# Altium schematic sheet Top.SchDoc(Top)
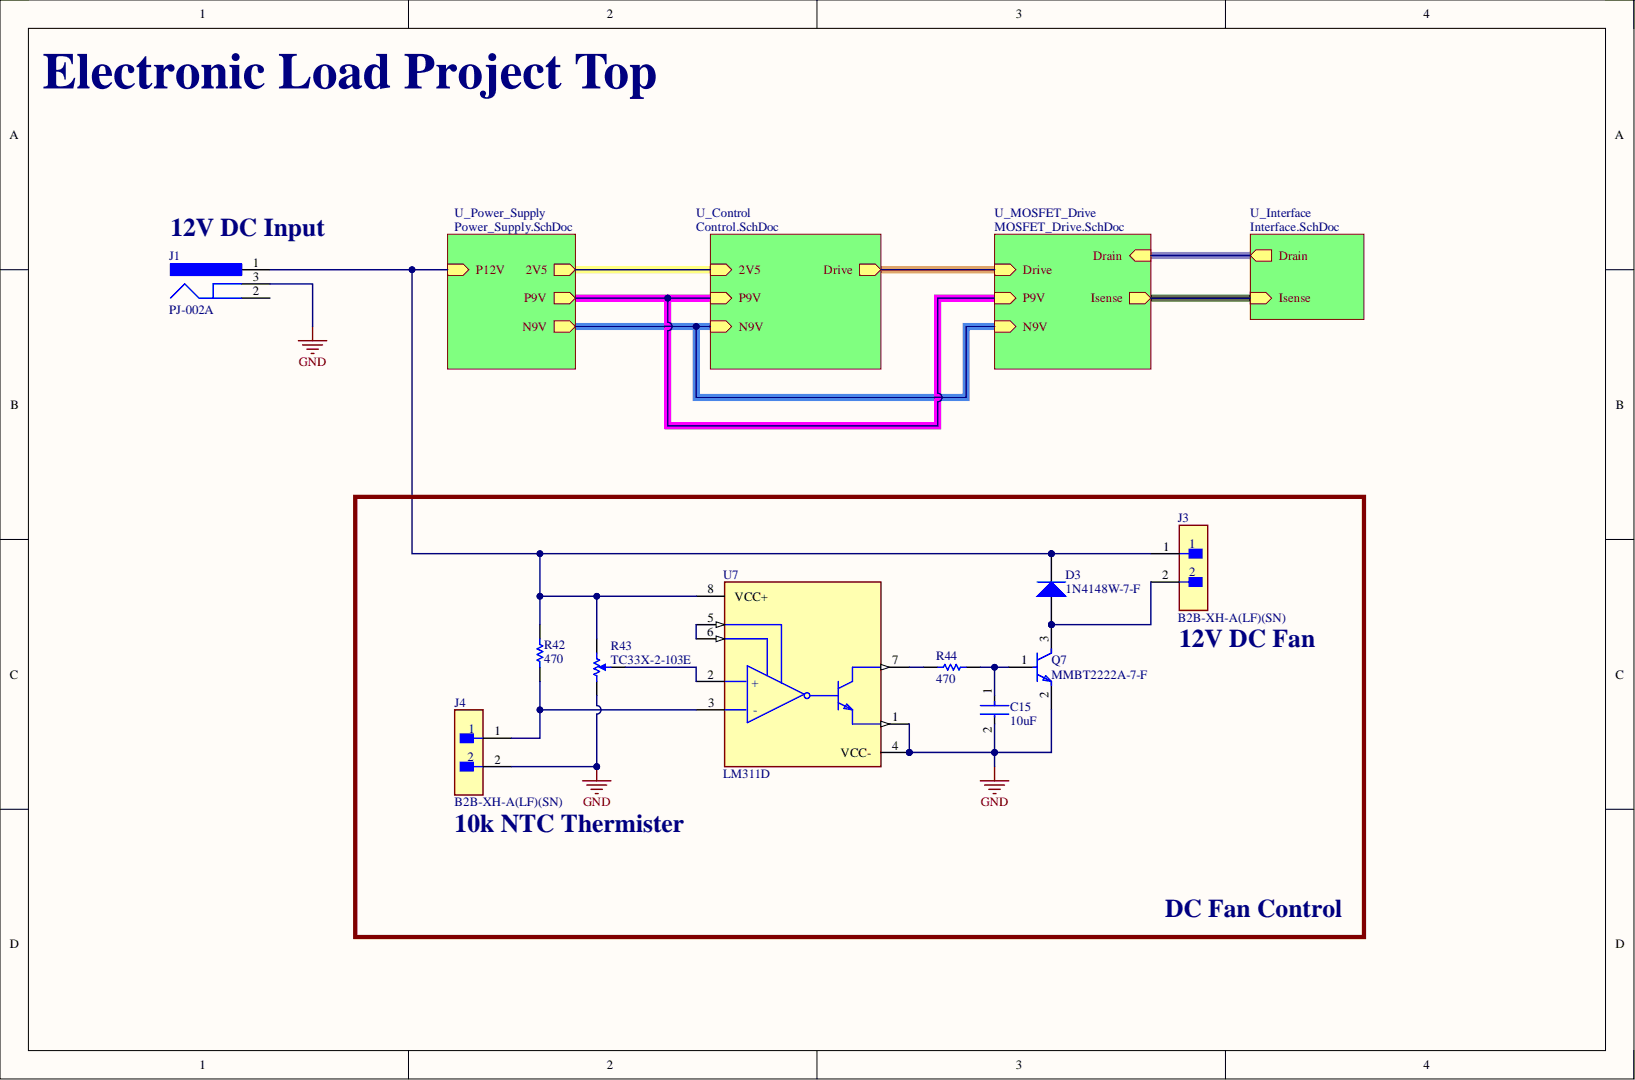
[diagram p0: Top.SchDoc]

## Parts
C15  10uF  [FP-C1210C107M9PACTU-MFG]
D3  1N4148W-7-F  [DIOD-SOD123-2_V]
J1  PJ-002A  [CUI-PJ-002A_V]
J3  B2B-XH-A(LF)(SN)  [FP-B2B-XH-A_LF_SN-MFG]
J4  B2B-XH-A(LF)(SN)  [FP-B2B-XH-A_LF_SN-MFG]
Q7  MMBT2222A-7-F  [FP-SOT23-IPC_A]
R42  470  [RESC2013X70X40NL20T20]
R43  TC33X-2-103E  [BOUR-TC33X-2_V]
R44  470  [RESC2013X70X40NL20T20]
U7  LM311D  [FP-D0008A-MFG]

## Nets
GND: C15.2 J1.3 J4.2 Q7.2 R43.1 U7.1 U7.4(VCC-)
NetC15_1: C15.1 Q7.1 R44.2
NetD3_1: D3.1 J3.2 Q7.3
NetD3_2: D3.2 J1.1 J3.1 R42.2 R43.3 U7.8(VCC+)
NetJ1_2: J1.2
NetJ4_1: J4.1 R42.1 U7.3
NetR43_2: R43.2 U7.2
NetR44_1: R44.1 U7.7
NetU7_5: U7.5 U7.6
2V5:
Drain:
Drive:
Isense:
N9V:
P9V:

## Library data of the parts
C15 = CMP-2000-06018-2: C1210C107M9PACTU  CAP CER 100UF 6.3V X5R 1210; Capacitance: 2.2uF; Dielectric Material: Ceramic; Dissipation Factor: 5%; Tolerance: 20%; Case Code (Imperial): 1210; Case Code (Metric): 3225; Case/Package: 1210; Component Kind: Standard; Construction: Flat; Contact Plating: Tin; Depth: 2.5mm; Dielectric: X5R; Height: 2.5mm; Lead Free: Lead Free; Length: 3.2mm; Library Name: Altium Content Vault; Max Operating Temperature: 85°C; Min Operating Temperature: -55°C; Mount: Surface Mount; Number of Pins: 2; Package Quantity: 1; Packaging: Tape and Reel; Radiation Hardening: No; REACH SVHC: No SVHC; RoHS Compliant: Yes; Series: C; Termination: SMD/SMT; Thickness: 0.11inch; Voltage: 6.3V; Voltage Rating: 6.3V; Voltage Rating (DC): 6.3V; Width: 2.5mm
D3 = CMP-2000-04932-2: Fast Switching Diode, 100 V, 0.15 A, -65 to 150 degC, 2-Pin?SOD123, RoHS, Tape and Reel; Brand: DiodesIncorporated; Configuration: Single; If - Forward Current: 0.15A; Ir - Reverse Current: 1uA; Max Surge Current: 2A; Peak Reverse Voltage: 100V; Recovery Time: 4ns; Unit Weight: 0.000353oz; Vf - Forward Voltage: 1.25V; Component Kind: Standard; Datasheet URL: http://www.farnell.com/datasheets/1915529.pdf; Datasheet Version: Rev. 24-2, 10/2013; Factory Pack Quantity: 3000; Height: 1.25mm; Length: 2.85mm; Library Name: Altium Content Vault; Manufacturer: DiodesIncorporated; Manufacturer URL: http://www.diodes.com/; Maximum Operating Temperature: +150C; Minimum Operating Temperature: -65C; Mounting Style: SMD/SMT; Operating Temperature Range: -65Cto+150C; Package / Case: SOD-123; Package Reference: SOD123-2; Package URL: http://www.diodes.com/datasheets/ds18004.pdf; Packaging: Reel; Product: SwitchingDiodes; Product Category: Diodes-GeneralPurpose,Power,Switching; RoHS: True; Series: 1N4148; Type: SwitchingDiode; Width: 1.7mm
J1 = CMP-2000-06895-2: Through Hole Right Angle DC Power Jack, 2.5 A, 2 mm Center Pin, 3 Position, -25 to 85 degC, RoHS, Bulk; Manufacturer: CUIInc.; Brand: CUI; Current Rating: 2.5A; Inside Contact Diameter: 2mm; Insulation Resistance: 50MOhms; Outside Contact Diameter: 6.5mm; Voltage Rating: 24V; Component Kind: Standard; Connector Type: DCPowerJack; Contact Gender: Male; Contact Material: Copper; Contact Plating: Nickel; Datasheet URL: http://www.cui.com/product/resource/pj-002a.pdf; Datasheet Version: Rev. 1.03, 04/2016; Factory Pack Quantity: 500; Flammability Rating: UL94V-0; Housing Material: PolybutyleneTerephthalate(PBT); Library Name: Altium Content Vault; Manufacturer URL: http://www.cui.com/; Mounting Angle: Right; Mounting Style: ThroughHole; Mounting Technology: ThroughHole; Operating Temperature Range: -25Cto+85C; Package Description: 3-Pin Through Hole Device, Body 14.4 x 9 mm, Height 11 mm; Package Reference: PJ-002A; Product: Jacks; Product Category: DCPowerConnectors; RoHS: True; Termination Style: ThroughHole
J3 J4 = CMP-2000-05888-3: CONN HEADER VERT 2POS 2.5MM; Connector Type: Male Pin; Contact Resistance: 20mR; Current Rating: 3A; Insulation Resistance: 1GR; Max Voltage Rating (AC): 250V; Number of Contacts: 2; Wire/Cable Gauge: 22AWG; Color: Natural; Component Kind: Standard; Contact Material: Brass; Contact Plating: Tin; Contact Type: Male Pin; Depth: 5.75mm; Fastening Type: Detent; Housing Color: White; Housing Material: Polyamide; Lead Free: Lead Free; Lead Pitch: 2.5mm; Length: 7.4mm; Library Name: Altium Content Vault; Max Current Rating: 3A; Max Operating Temperature: 85°C; Max Voltage Rating (DC): 250V; Min Operating Temperature: -25°C; Mount: Through Hole; Number of Positions: 2; Number of Rows: 1; Orientation: Straight; Packaging: Bulk; Pitch: 2.5mm; REACH SVHC: No SVHC; Series: XH; Termination: Solder; Voltage Rating: 250V; Voltage Rating (AC): 250V
Q7 = CMP-1693-00003-4: TRANS NPN 40V 0.6A SMD SOT23-3; Collector Base Voltage (VCBO): 75V; Collector Emitter Saturation Voltage: 1V; Continuous Collector Current: 600mA; Current: 1A; Element Configuration: Single; Emitter Base Voltage (VEBO): 6V; Frequency: 300MHz; hFE Min: 100; Max Power Dissipation: 300mW; Number of Elements: 1; Power Dissipation: 350mW; Case/Package: SOT-23; Collector Emitter Breakdown Voltage: 40V; Collector Emitter Voltage (VCEO): 40V; Component Kind: Standard; Contact Plating: Tin; Current Rating: 600mA; Gain Bandwidth Product: 300MHz; Height: 1mm; Lead Free: Lead Free; Length: 3.05mm; Library Name: Altium Content Vault; Max Breakdown Voltage: 40V; Max Collector Current: 600mA; Max Frequency: 300MHz; Max Operating Temperature: 150°C; Min Operating Temperature: -55°C; Mount: Surface Mount; Number of Pins: 3; Package Quantity: 3000; Packaging: Tape and Reel; Polarity: NPN; Radiation Hardening: No; REACH SVHC: No SVHC; Termination: SMD/SMT; Transition Frequency: 300MHz; Voltage: 40V; Voltage Rating (DC): 40V; Weight: 0.000282oz; Width: 1.4mm
R42 R44 = CMP-00023-1: 0805, 0.125W, 1%, Low PPM; Max Power Dissipation: 125mW; Resistance Tolerance: 5%; Temperature Coefficient: 200ppm/°C; Voltage Rating: 150V; Case Code (Imperial): 0805; Case Code (Metric): 2012; Case/Package: 0805; Component Kind: Standard; Construction: Rectangular; Contact Plating: Tin; Depth: 1.25mm; Height: 0.6mm; Length: 2mm; Max Operating Temperature: 155°C; Military Standard: Not; Min Operating Temperature: -55°C; Mounting Technology: Surface Mount; Number of Terminations: 2; Package Quantity: 1; Packaging: Tape and Reel; Power Rating: 125mW; Radiation Hardening: No; Reach SVHC Compliant: No; Resistor Type: Thick Film; Series: ERJ; Technology: THICK FILM; Termination: SMD/SMT; Tolerance: 5%; Value: 470; Width: 1.25mm; Library Name: UBC AeroDesign
R43 = CMP-2100-03695-1: Trimming Potentiometer, 10000 Ohm, -40 to 100 degC, 3-Pin SMD, RoHS, Tape and Reel; Manufacturer: Bourns; Max Power Dissipation: 100mW; Number of Turns: 1; Resistance: 10KOhm; Temperature Coefficient: 250ppm/degreesC; Tolerance: 25%; Voltage Rating (DC): 50V; Case/Package: SMD/SMT; Colour: Silver; Component Kind: Standard; Composition: Potentiometer; Contact Plating: Silver; Datasheet URL: http://www.bourns.com/docs/Product-Datasheets/TC33.pdf; Datasheet Version: 42583.0; Depth: 3.8mm; Height: 0.047inch; Lead Free: LeadFree; Length: 3mm; Library Name: Altium Content Vault; Manufacturer URL: http://www.bourns.com/; Max Operating Temperature: 100degC; Military Standard: Not; Min Operating Temperature: -40degC; Mount: SurfaceMount; Package Description: 3-Pin Surface Mount Device, Body 3.8 x 3.6 mm; Package Quantity: 2500; Package Reference: TC33X-2; Packaging: TapeandReel; Power Rating: 100mW; Radiation Hardening: No; REACH SVHC: NoSVHC; RoHSCompliant: true; Taper: Linear; Termination: FootPrint; Weight: 29.4mg; Width: 0.118inch
U7 = CMP-04896-000022-1: IC DIFF COMP W/STROBE 8-SOIC; Manufacturer: Texas Instruments; Input Bias Current: 0.1uA; Input Offset Voltage: 2mV; Max Junction Temp: +150°C; Max Supply Voltage: 30V; Min Supply Voltage: 3.5V; Nominal Supply Current: 5.1mA; Number Of Channels: 1; Response Time: 165ns; Standoff Height: 0.11mm; Voltage Gain: 200V/mV; Ambient Temperature Range High: +70°C; Ambient Temperature Range Low: 0°C; Automotive: No; Category: IC; Component Kind: Standard; ComponentLink2Description: Datasheet; ComponentLink2URL: http://www.ti.com/lit/ds/symlink/lm311.pdf; Device Class L1: Integrated Circuits (ICs); Device Class L2: Linear ICs; Device Class L3: Comparators; Digikey Description: IC DIFF COMP W/STROBE 8-SOIC; Height: 1.75mm; Ipc Land Pattern Name: SOIC127P600X175-8; Lead Free: Yes; Library Name: Altium Content Vault; Manufacturer Part Number: LM311D; Mouser Description: Analog Comparators Single Channler; Octopart: https://octopart.com/lm311d-texas+instruments-428348; Package: D0008A; RoHS: TRUE; Temperature: 0°C to +70°C; Temperature Range High: +70°C; Temperature Range Low: 0°C
